# Altium schematic sheet 07_PS2_Joystick.SchDoc
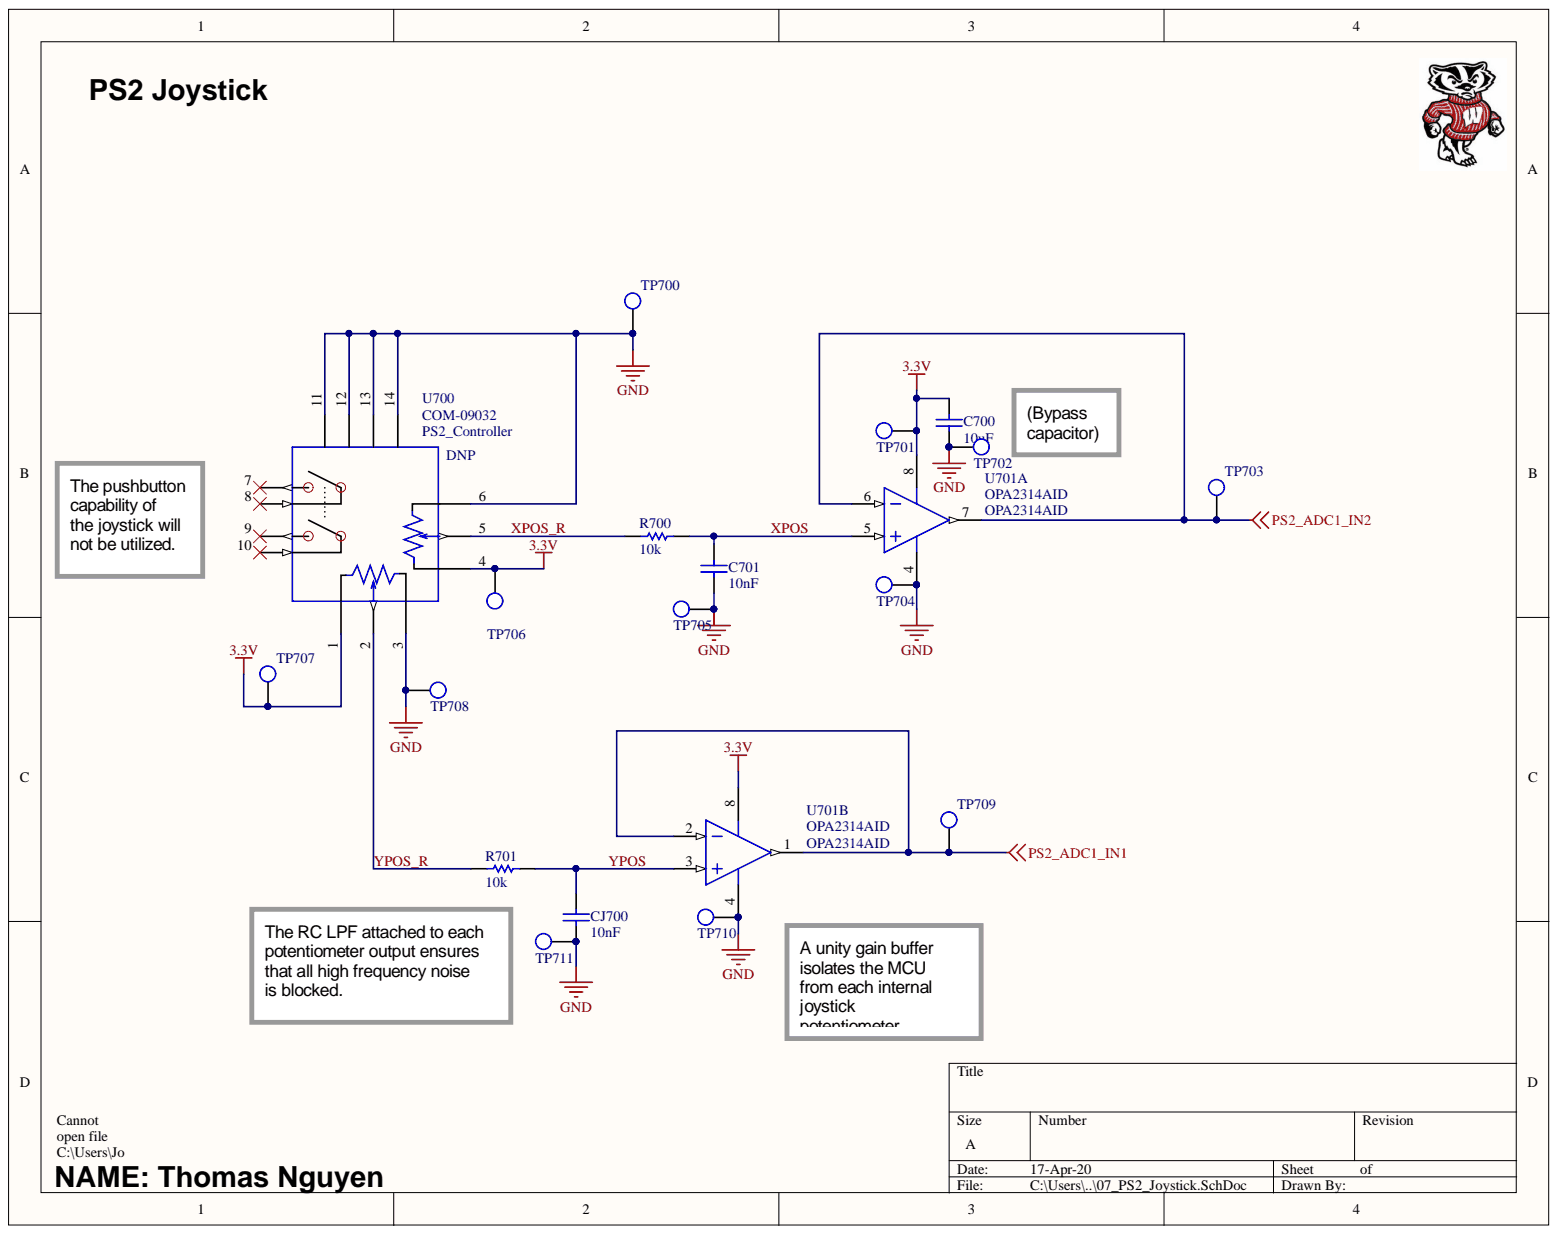
[diagram p0: 07_PS2_Joystick.SchDoc]

## Parts
C700  10uF  [CAP_0805]
C701  Capacitance: 10nF  (hidden comment: CAP_0805_N_010.00)
CJ700  Capacitance: 10nF  (hidden comment: CAP_0805_N_010.00)
R700  10K  [RES_0805]
R701  10K  [RES_0805]
TP700  TP_1206  [TP-SMC-1206]  (value not drawn)
TP701  TP_1206  [TP-SMC-1206]  (value not drawn)
TP702  TP_1206  [TP-SMC-1206]  (value not drawn)
TP703  TP_1206  [TP-SMC-1206]  (value not drawn)
TP704  TP_1206  [TP-SMC-1206]  (value not drawn)
TP705  TP_1206  [TP-SMC-1206]  (value not drawn)
TP706  TP_1206  [TP-SMC-1206]  (value not drawn)
TP707  TP_1206  [TP-SMC-1206]  (value not drawn)
TP708  TP_1206  [TP-SMC-1206]  (value not drawn)
TP709  TP_1206  [TP-SMC-1206]  (value not drawn)
TP710  TP_1206  [TP-SMC-1206]  (value not drawn)
TP711  TP_1206  [TP-SMC-1206]  (value not drawn)
U700  COM-09032  Name: PS2_Controller
U701  OPA2314AID  [D0008A_N]  (parts A,B)

## Nets
3.3V: C700.1 TP701.1 TP706.1 TP707.1 U700.1 U700.4 U701.8
GND: C700.2 C701.1 CJ700.1 TP700.1 TP702.1 TP704.1 TP705.1 TP708.1 TP710.1 TP711.1 U700.3 U700.6 U700.11 U700.12 U700.13 U700.14 U701.4
PS2_ADC1_IN1: TP709.1 U701.1 U701.2
PS2_ADC1_IN2: TP703.1 U701.6 U701.7
XPOS: C701.2 R700.2 U701.5
XPOS_R: R700.1 U700.5
YPOS: CJ700.2 R701.2 U701.3
YPOS_R: R701.1 U700.2

Not connected: U700 7 8 9 10

## Library data of the parts
C700 = CAP_0805_U_010.00: CAP CER 10UF 16V X7R 0805; Manufacturer: Samsung; Manufacturer Part Number: CL21A106KPCLQNC; Dielectric: X5R; Tolerance: 10%; Voltage Rating: 10V; Capacitance: 10uF; Case/Package: 0805; Case Code (Imperial): 0805; Case Code (Metric): 2012; Component Kind: Standard; ComponentLink1Description: https://datasheet.ciiva.com/25730/cl21a106kpclqnc-25730594.pdf; ComponentLink1URL: https://datasheet.ciiva.com/25730/cl21a106kpclqnc-25730594.pdf; ComponentLink3Description: https://datasheet.ciiva.com/26920/getdatasheetpartid-355170-26920201.pdf; ComponentLink3URL: https://datasheet.ciiva.com/26920/getdatasheetpartid-355170-26920201.pdf; Contact Plating: Tin; Depth: 1.25mm; Height: 0.85mm; Length: 2mm; Library Name: ece315-S20.SCHLIB; Max Operating Temperature: 85°C; Min Operating Temperature: -55°C; Mount: Surface Mount; Package Quantity: 1; Packaging: Tape and Reel; Pricing 1: 1=0.22, 10=0.148, 100=0.0749, 500=0.05122, 1000=0.04531 (USD); Radiation Hardening: No; Stock 1: 40000; Supplier 1: Digi-Key; Supplier Part Number 1: 1276-2402-1-ND; Thickness: 0.037inch; Width: 0.049inch
C701 CJ700 = CAP_0805_N_010.00: KEMET - C0603C103KARACAUTO - SMD Multilayer Ceramic Capacitor, 10000 pF, 250 V, 0603 [1608 Metric], ± 10%, X7R; Voltage Rating: 250V; Case/Package: 0603; Case Code (Imperial): 0603; Case Code (Metric): 1608; Component Kind: Standard; ComponentLink1Description: http://www.farnell.com/datasheets/2748306.pdf?src-supplier=Farnell; ComponentLink1URL: http://www.farnell.com/datasheets/2748306.pdf?src-supplier=Farnell; ComponentLink2Description: http://www.farnell.com/datasheets/2748306.pdf?src-supplier=Newark; ComponentLink2URL: http://www.farnell.com/datasheets/2748306.pdf?src-supplier=Newark; ComponentLink3Description: http://www.farnell.com/datasheets/2748306.pdf?src-supplier=Element14; ComponentLink3URL: http://www.farnell.com/datasheets/2748306.pdf?src-supplier=Element14; ComponentLink4Description: https://api.kemet.com/component-edge/download/datasheet/C0603C103KARACAUTO.pdf?src-supplier=Digi-Key; ComponentLink4URL: https://api.kemet.com/component-edge/download/datasheet/C0603C103KARACAUTO.pdf?src-supplier=Digi-Key; Library Name: ece315-S20.SCHLIB; Manufacturer: KEMET; Manufacturer Part Number: C0603C103KARACAUTO; Max Operating Temperature: 125°C; Min Operating Temperature: -55°C; Mount: Surface Mount; Packaging: Tape and Reel; Pricing 1: 1=0.95000, 10=0.72000, 100=0.51490, 500=0.39862, 1000=0.34326 (USD); Ratings: AEC-Q200; RoHS: No; Stock 1: 11770; Supplier 1: Digi-Key; Supplier Part Number 1: 399-17960-1-ND; Tolerance: 10%
R700 R701 = RES_0805_K_010.00: RES SMD 10K OHM 1% 1/8W 0805; Manufacturer: Panasonic / Panasonic ECG; Manufacturer Part Number: ERJ-6ENF1002V; No. of Pins: 2; Power Rating: 125mW; Product Range: PANASONIC - ERJ Series; Resistor Case Style: 0805; Resistor Element Material: Thick Film; Temperature Coefficient: ± 200ppm/°C; Voltage Rating: 150V; Component Kind: Standard; ComponentLink1Description: https://datasheet.ciiva.com/11808/0900766b80f80784-11808080.pdf; ComponentLink1URL: https://datasheet.ciiva.com/11808/0900766b80f80784-11808080.pdf; ComponentLink2Description: https://datasheet.ciiva.com/16514/aoa0000ce2-16514021.pdf; ComponentLink2URL: https://datasheet.ciiva.com/16514/aoa0000ce2-16514021.pdf; ComponentLink3Description: https://datasheet.ciiva.com/27055/1988971-27055733.pdf; ComponentLink3URL: https://datasheet.ciiva.com/27055/1988971-27055733.pdf; ComponentLink4Description: https://datasheet.ciiva.com/16759/70260748-16759350.pdf; ComponentLink4URL: https://datasheet.ciiva.com/16759/70260748-16759350.pdf; ComponentLink5Description: https://datasheet.ciiva.com/16725/70260748-16725031.pdf; ComponentLink5URL: https://datasheet.ciiva.com/16725/70260748-16725031.pdf; ComponentLink6Description: https://datasheet.ciiva.com/7830/426256-7830745.pdf; ComponentLink6URL: https://datasheet.ciiva.com/7830/426256-7830745.pdf; ComponentLink7Description: https://datasheet.ciiva.com/46372/1678782-46372714.pdf; ComponentLink7URL: https://datasheet.ciiva.com/46372/1678782-46372714.pdf; ComponentLink8Description: https://datasheet.ciiva.com/46372/1678782-46372715.pdf; ComponentLink8URL: https://datasheet.ciiva.com/46372/1678782-46372715.pdf; ComponentLink9Description: https://datasheet.ciiva.com/17212/aoa0000ce2-17212108.pdf; ComponentLink9URL: https://datasheet.ciiva.com/17212/aoa0000ce2-17212108.pdf; ComponentLink10Description: https://datasheet.ciiva.com/30140/aoa0000ce2-30140236.pdf; ComponentLink10URL: https://datasheet.ciiva.com/30140/aoa0000ce2-30140236.pdf; ComponentLink11Description: https://datasheet.ciiva.com/16740/aoa0000ce2-16740669.pdf; ComponentLink11URL: https://datasheet.ciiva.com/16740/aoa0000ce2-16740669.pdf; ComponentLink12Description: https://datasheet.ciiva.com/24070/aoa0000ce2-24070702.pdf; ComponentLink12URL: https://datasheet.ciiva.com/24070/aoa0000ce2-24070702.pdf; Library Name: ece315-S20.SCHLIB; Pricing 1: 1=0.1, 10=0.041, 100=0.0167, 1000=0.0075, 2500=0.0065 (USD); Resistance: 10k; Resistance Tolerance: ± 1%; Resistor Element Type: Thick Film; Resistor Mounting: SMD; Series: ERJ; Stock 1: 87; Supplier 1: Digikey; Supplier Part Number 1: P10.0KCCT-ND
TP700-TP711 = TP_1206: Test Point SMD 1206; Manufacturer: TE Connectivity (Tyco Electronics); Manufacturer Part Number: 1625854-1; Power Rating: N/R; Resistance Value: 0 Ohm; Case Size: 1206; Component Kind: Standard; ComponentLink1Description: https://datasheet.ciiva.com/6439/eng-ds-1773266-a-6439169.pdf; ComponentLink1URL: https://datasheet.ciiva.com/6439/eng-ds-1773266-a-6439169.pdf; ComponentLink2Description: https://datasheet.ciiva.com/30136/1773266-a-30136341.pdf; ComponentLink2URL: https://datasheet.ciiva.com/30136/1773266-a-30136341.pdf; ComponentLink3Description: https://datasheet.ciiva.com/6439/eng-ds-1773266-a-6439080.pdf; ComponentLink3URL: https://datasheet.ciiva.com/6439/eng-ds-1773266-a-6439080.pdf; ComponentLink4Description: https://datasheet.ciiva.com/6439/eng-ds-1773266-a-6439081.pdf; ComponentLink4URL: https://datasheet.ciiva.com/6439/eng-ds-1773266-a-6439081.pdf; ComponentLink5Description: https://datasheet.ciiva.com/6439/eng-ds-1773266-a-6439083.pdf; ComponentLink5URL: https://datasheet.ciiva.com/6439/eng-ds-1773266-a-6439083.pdf; Dimension: 3.2 x 1.6 x 1.25mm; Family: RC; Library Name: ece315-S20.SCHLIB; Mounting: Surface Mount; Operating Temperature: -55 to 125 C; Packaging: Tape and Reel; Part No: 1625854-1; Pricing 1: 1=0.28, 10=0.261, 25=0.224, 50=0.1902, 100=0.1828, 250=0.1641, 500=0.1567, 1000=0.1306 (USD); RoHS: Yes; Stock 1: 31937; Supplier 1: Digi-Key; Supplier Part Number 1: A106143CT-ND; Temperature Coefficient: N/R; Terminal Pitch: N/R; Termination Style: N/R; Tolerance: N/R
U700 = COM-09032: JOYSTICK 10K OHM 2 AXIS TH; Manufacturer: SparkFun; Component Kind: Standard; ComponentLink1Description: https://media.digikey.com/pdf/Data%20Sheets/Sparkfun%20PDFs/COM-09032_Web.pdf?src-supplier=Digi-Key; ComponentLink1URL: https://media.digikey.com/pdf/Data%20Sheets/Sparkfun%20PDFs/COM-09032_Web.pdf?src-supplier=Digi-Key; Library Name: ece315-S20.SCHLIB; Manufacturer Part Number: COM-09032; Pricing 1: 1=3.95000 (USD); RoHS: No; Stock 1: 773; Supplier 1: Digi-Key; Supplier Part Number 1: 1568-1526-ND
U701 = OPA2314AID: Dual, 3MHz, Low-Power, Low-Noise, I/O, 1.8V CMOS Operational Amplifier 8-SOIC -40 to 125; Manufacturer: Texas Instruments; Common Mode Rejection Ratio: 75dB; Input Bias Current: 10pA; Input Offset Voltage (Vos): 2.5mV; Max Dual Supply Voltage: 2.75V; Max Supply Voltage: 5.5V; Min Dual Supply Voltage: 9V; Nominal Supply Current: 150uA; Number of Amplifiers: 2; Output Current: 20mA; Power Supply Rejection Ratio (PSRR): 78dB; Slew Rate: 1.5V/µs; Voltage Gain: 128dB; Bandwidth: 3MHz; Case/Package: D; Category: Amplifiers - Op Amps, Buffer, Instrumentation; Component Kind: Standard; ComponentLink1Description: https://datasheet.ciiva.com/25630/0900766b8119b04f-25630783.pdf?src-supplier=RSComponents; ComponentLink1URL: https://datasheet.ciiva.com/25630/0900766b8119b04f-25630783.pdf?src-supplier=RSComponents; ComponentLink2Description: https://datasheet.ciiva.com/7848/1634049-7848148.pdf?src-supplier=Element14; ComponentLink2URL: https://datasheet.ciiva.com/7848/1634049-7848148.pdf?src-supplier=Element14; ComponentLink3Description: https://datasheet.ciiva.com/7219/1740466-7219734.pdf?src-supplier=Newark; ComponentLink3URL: https://datasheet.ciiva.com/7219/1740466-7219734.pdf?src-supplier=Newark; ComponentLink4Description: https://datasheet.ciiva.com/14456/opa2314-14456263.pdf?src-supplier=Avnet; ComponentLink4URL: https://datasheet.ciiva.com/14456/opa2314-14456263.pdf?src-supplier=Avnet; ComponentLink5Description: https://datasheet.ciiva.com/6627/opa2314-6627677.pdf?src-supplier=Digi-Key; ComponentLink5URL: https://datasheet.ciiva.com/6627/opa2314-6627677.pdf?src-supplier=Digi-Key; ComponentLink6Description: https://datasheet.ciiva.com/48706/001071969-da-01-en-ic-opamp-gp-rrio-3-opa2314aid-soic-8-tid-48706620.pdf?src-supplier=Conrad; ComponentLink6URL: https://datasheet.ciiva.com/48706/001071969-da-01-en-ic-opamp-gp-rrio-3-opa2314aid-soic-8-tid-48706620.pdf?src-supplier=Conrad; Gain Bandwidth Product: 3MHz; Height: 1.5mm; Lead Free: Lead Free; Length: 5mm; Library Name: ece315-S20.SCHLIB; Manufacturer Part Number: OPA2314AID; Max I/O Voltage: 2.5mV; Max Operating Temperature: 125°C; Min Operating Temperature: -40°C; Min Supply Voltage: 1.8V; Nominal Gain Bandwidth Product: 3MHz; Number of Channels: 2; Number of Circuits: 2; Number of Elements: 2; Number of Pins: 8; Operating Supply Current: 150uA; Output Current per Channel: 20mA; Package Quantity: 75; Packaging: Rail/Tube; Pricing 1: 1=1.62000, 10=1.45800, 25=1.37520, 100=1.10000, 250=0.96252, 500=0.93500, 1000=0.74250, 2500=0.71500, 5000=0.68750 (USD); Radiation Hardening: No; REACH SVHC: No SVHC; RoHS: Yes; Stock 1: 429; Supplier 1: Digi-Key; Supplier Part Number 1: 296-30336-5-ND; Unity Gain Bandwidth Product: 3MHz; Width: 4mm
